# Altium schematic sheet LMcude-F_01.SchDoc(LMcude-F_01)
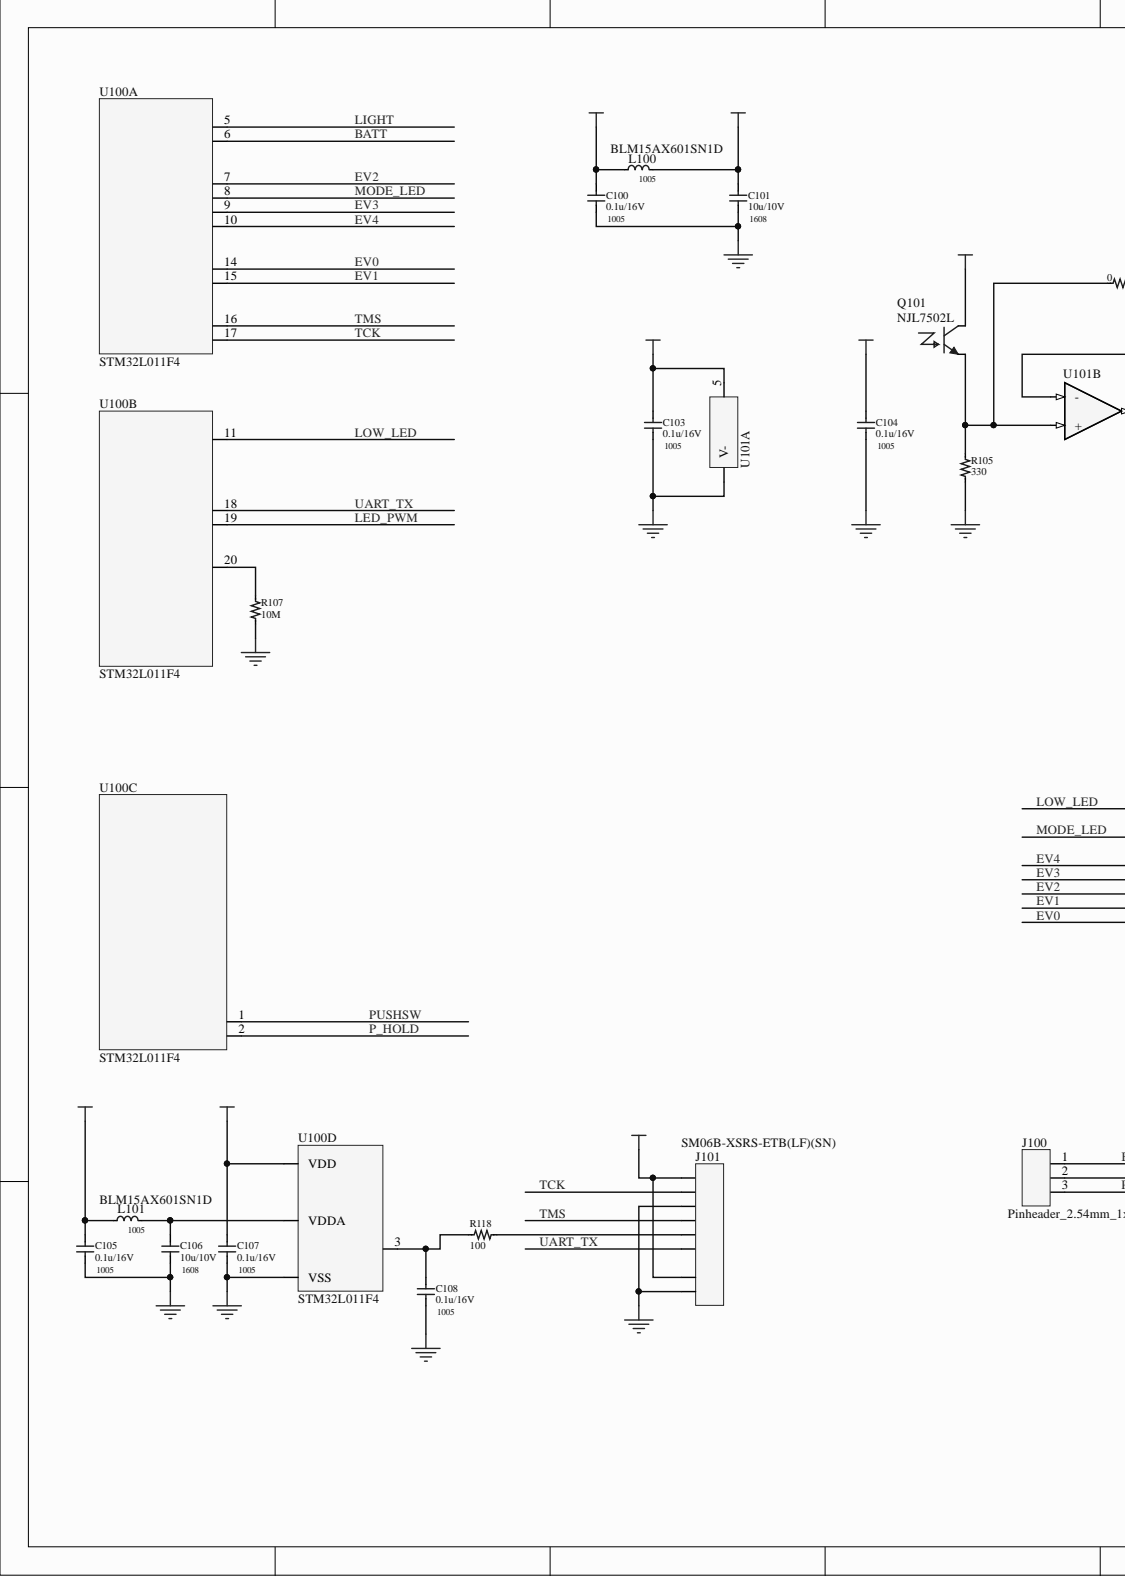
[diagram p0: LMcude-F_01.SchDoc, left]
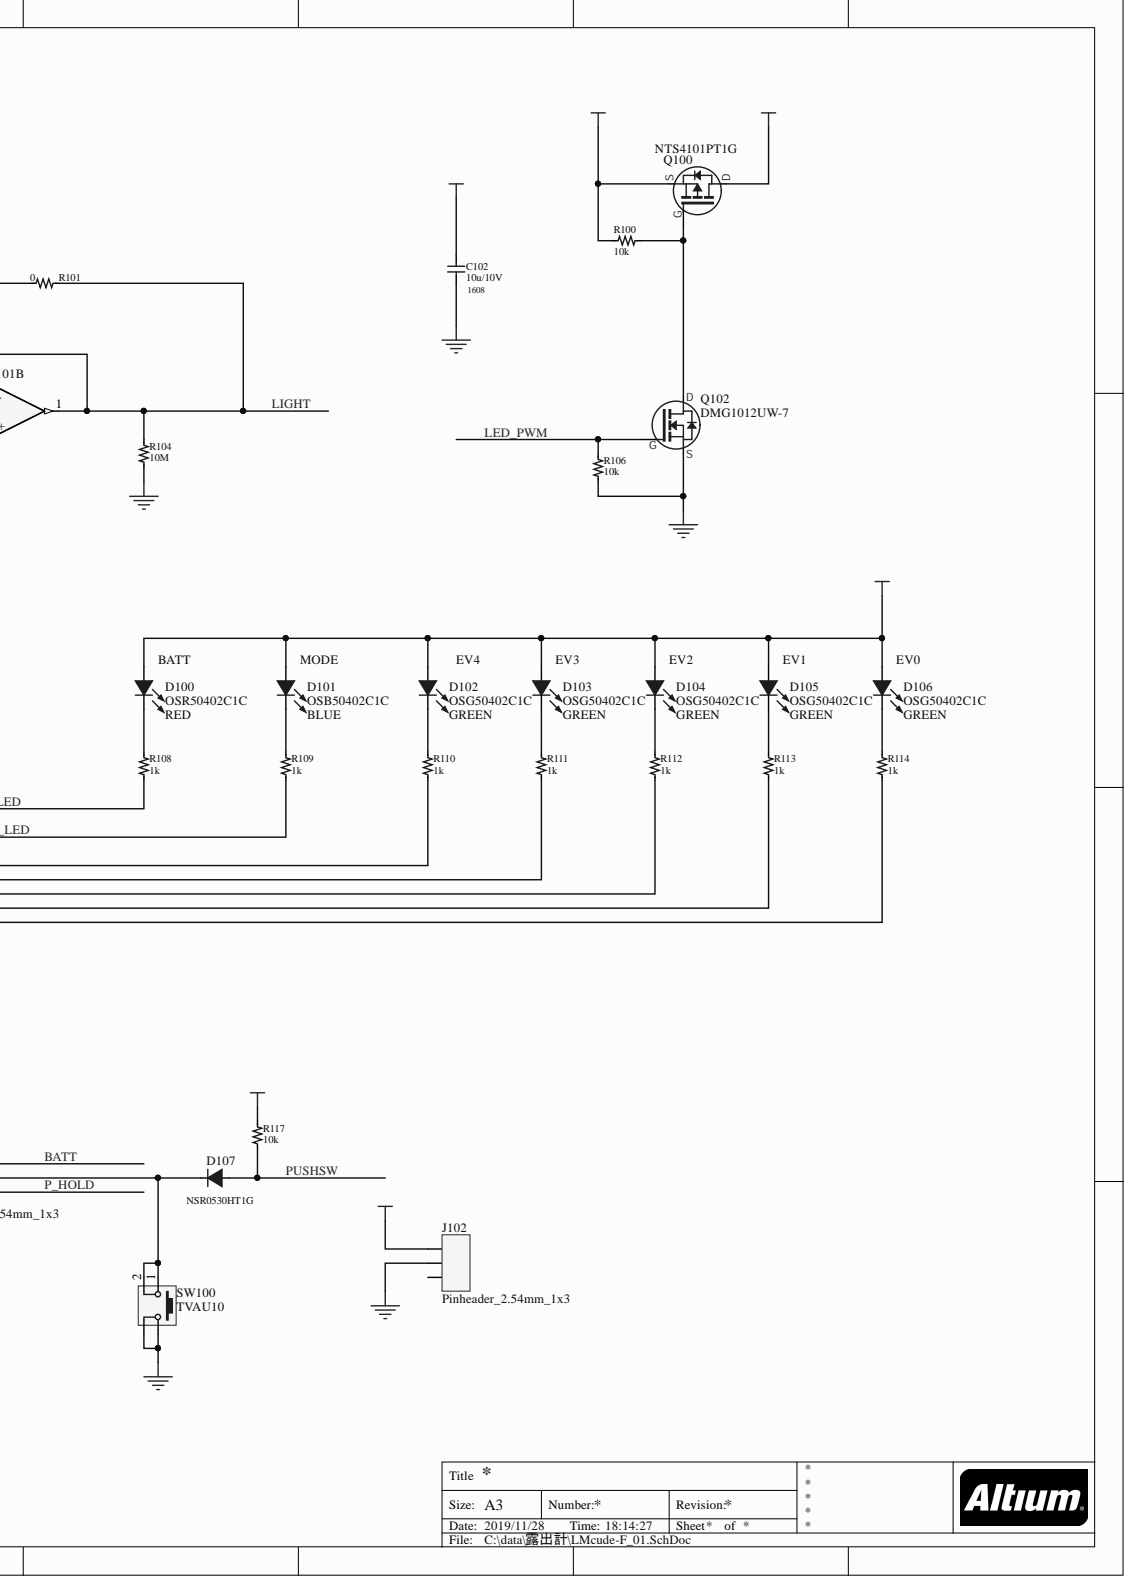
[diagram p1: LMcude-F_01.SchDoc, right]

## Parts
C100  0.1u/16V  Footprint(metric): 1005  [SC2-1.00X0.50A]
C101  10u/10V  Footprint(metric): 1608  [SC2-1.60X0.80A]
C102  10u/10V  Footprint(metric): 1608  [SC2-1.60X0.80A]
C103  0.1u/16V  Footprint(metric): 1005  [SC2-1.00X0.50A]
C104  0.1u/16V  Footprint(metric): 1005  [SC2-1.00X0.50A]
C105  0.1u/16V  Footprint(metric): 1005  [SC2-1.00X0.50A]
C106  10u/10V  Footprint(metric): 1608  [SC2-1.60X0.80A]
C107  0.1u/16V  Footprint(metric): 1005  [SC2-1.00X0.50A]
C108  0.1u/16V  Footprint(metric): 1005  [SC2-1.00X0.50A]
D100  OSR50402C1C  Color: RED  [LED 1005]
D101  OSB50402C1C  Color: BLUE  [LED 1005]
D102  OSG50402C1C  Color: GREEN  [LED 1005]
D103  OSG50402C1C  Color: GREEN  [LED 1005]
D104  OSG50402C1C  Color: GREEN  [LED 1005]
D105  OSG50402C1C  Color: GREEN  [LED 1005]
D106  OSG50402C1C  Color: GREEN  [LED 1005]
D107  NSR0530HT1G  [SOD-323 Onsemi]
J100  Pinheader_2.54mm_1x3  [Pinheader_2.54mm_1x3]
J101  SM06B-XSRS-ETB(LF)(SN)  [SM06B-XSRS-RTB(LF)(SN)]
J102  Pinheader_2.54mm_1x3  [Pinheader_2.54mm_1x3]
L100  BLM15AX601SN1D  Footprint(metric): 1005  [SL2-1.00X0.50A]
L101  BLM15AX601SN1D  Footprint(metric): 1005  [SL2-1.00X0.50A]
Q100  NTS4101PT1G  [ON Semiconductor SC-70(SOT-323)]
Q101  NJL7502L  [3mm DIP PHOTO Transister]
Q102  DMG1012UW-7  [DMG1012UW-7]
R100  10k  [SR2-1.00X0.50A]
R101  0  [SR2-1.00X0.50A]
R104  10M  [SR2-1.00X0.50A]
R105  330  [SR2-1.00X0.50A]
R106  10k  [SR2-1.00X0.50A]
R107  10M  [SR2-1.00X0.50A]
R108  1k  [SR2-1.00X0.50A]
R109  1k  [SR2-1.00X0.50A]
R110  1k  [SR2-1.00X0.50A]
R111  1k  [SR2-1.00X0.50A]
R112  1k  [SR2-1.00X0.50A]
R113  1k  [SR2-1.00X0.50A]
R114  1k  [SR2-1.00X0.50A]
R117  10k  [SR2-1.00X0.50A]
R118  100  [SR2-1.00X0.50A]
SW100  TVAU10  [Switch_TVAU10]
U100  STM32L011F4  [STM32 UFQFPN20]  (parts A,B,C,D)
U101  Manufacture Parts Number  [ABLIC SOT-23-5]  (value not drawn)  (parts A,B)

## Nets
3V3: C100.1 C102.1 C105.1 C107.1 J101.1 J101.FG1 J102.1 L100.1 L101.1 Q100.2 R100.2 R117.1 U100.13(VDD)
3V3LED: D100.A D101.A D102.A D103.A D104.A D105.A D106.A Q100.3
3V3P: C101.1 C103.1 C104.1 L100.2 Q101.C U101.5
GND: C100.2 C101.2 C102.2 C103.2 C104.2 C105.2 C106.2 C107.2 C108.2 J101.3 J101.FG2 J102.2 Q102.2 R104.1 R105.1 R106.2 R107.1 SW100.3 SW100.4 U100.12(VSS) U101.2(V-)
BATT: J100.1 U100.6
EV0: R114.2 U100.14
EV1: R113.2 U100.15
EV2: R112.2 U100.7
EV3: R111.2 U100.9
EV4: R110.2 U100.10
LED_PWM: Q102.1 R106.1 U100.19
LIGHT: R101.1 R104.2 U100.5 U101.1 U101.4(-)
LOW_LED: R108.2 U100.11
MODE_LED: R109.2 U100.8
P_HOLD: J100.3 U100.2
PUSHSW: D107.A R117.2 U100.1
TCK: J101.2 U100.17
TMS: J101.4 U100.16
UART_TX: J101.6 U100.18
NetC106_1: C106.1 L101.2 U100.4(VDDA)
NetC108_1: C108.1 R118.1 U100.3
NetD100_C: D100.C R108.1
NetD101_C: D101.C R109.1
NetD102_C: D102.C R110.1
NetD103_C: D103.C R111.1
NetD104_C: D104.C R112.1
NetD105_C: D105.C R113.1
NetD106_C: D106.C R114.1
NetD107_C: D107.C J100.2 SW100.1 SW100.2
NetJ101_5: J101.5 R118.2
NetQ100_1: Q100.1 Q102.3 R100.1
NetQ101_E: Q101.E R101.2 R105.2 U101.3(+)
NetR107_2: R107.2 U100.20

Not connected: J102 3

## Library data of the parts
C100 C103-C105 C107 C108 = C: CAP CER 0.1UF 16V X7R 0402; Manufacturer Part Number: 0.1uF/16V X7R 1005metric; Manufacturer: Any; Sample Shop: Digikey; Replace: ok; PCB P/N: LMcube; MP SPQ: unknown; Function Category: 5V DCDC; Commodity: MLCC; BOM Rev: 0.00; Value: 0.1u/16V; Sample URL: https://www.digikey.jp/product-detail/ja/murata-electronics/GCM155R71C104KA55J/490-7998-1-ND/4380283; MP Price: unknown; MP MOQ: unknown; MP L/T(w): unknown; MP Dist.: unknown; Footprint(imperial): 0402; Component Kind: Standard; Library Name: I_Lib_cronos.IntLib
C101 C102 C106 = C: CAP CER 4.7UF 16V X5R 0603; Manufacturer Part Number: LMK107BBJ106MALT; Manufacturer: Yuden; Sample Shop: Digikey; Replace: ok; PCB P/N: LMcube; MP SPQ: unknown; Function Category: Battery Charger; Commodity: MLCC; BOM Rev: 0.00; Value: 10u/10V; Sample URL: https://www.digikey.jp/product-detail/ja/taiyo-yuden/LMK107BBJ106MALT/587-3258-1-ND/3662218; MP Price: unknown; MP MOQ: unknown; MP L/T(w): unknown; MP Dist.: unknown; Footprint(imperial): 0603; Component Kind: Standard; Library Name: I_Lib_cronos.IntLib
D100-D106 = LED 1005: RGB LED SMT 5x5mm; Manufacturer: OptoSupply; Sample Shop: AKIDUKI; Replace: ng; PCB P/N: LMcube; MP SPQ: unknown; BOM Rev: 0.00; Value: LED 1005; MP Price: unknown; MP MOQ: unknown; MP L/T(w): unknown; MP Dist.: unknown; Function Category: unknown; Component Kind: Standard; Commodity: LED; Library Name: I_Lib_cronos.IntLib
  D100: Sample URL: http://akizukidenshi.com/catalog/g/gI-11717/; Manufacturer Part Number: OSR50402C1C
  D101: Sample URL: http://akizukidenshi.com/catalog/g/gI-11722/; Manufacturer Part Number: OSB50402C1C
  D102-D106: Sample URL: http://akizukidenshi.com/catalog/g/gI-11721/; Manufacturer Part Number: OSG50402C1C
D107 = NSR0530HT1G: Manufacturer: ONsemi; Sample Shop: Digikey; Replace: ng; PCB P/N: LMcube; MP SPQ: unknown; Function Category: Power Control; Commodity: Diode; BOM Rev: 0.00; Value: NSR0530HT1G; Sample URL: http://www.digikey.jp/product-detail/ja/on-semiconductor/NSR0530HT1G/NSR0530HT1GOSDKR-ND/5761699; MP Price: unknown; MP MOQ: unknown; MP L/T(w): unknown; MP Dist.: unknown; Manufacturer Part Number: NSR0530HT1G; Component Kind: Standard; Library Name: I_Lib_cronos.IntLib
J100 J102 = Con3: Manufacturer Part Number: 2.54mm Lowprofile Header; Manufacturer: Neltron Industrial Co., Ltd.; Sample Shop: AKIDUKI; Replace: ng; PCB P/N: LMcube; MP SPQ: unknown; BOM Rev: 0.00; Value: Pinheader_2.54mm_1x3; Sample URL: http://akizukidenshi.com/catalog/g/gC-02900/; MP Price: unknown; MP MOQ: unknown; MP L/T(w): unknown; MP Dist.: unknown; Function Category: unknown; Component Kind: Standard; Commodity: unknown; Library Name: I_Lib_cronos.IntLib
J101 = Con6 with FG: Manufacturer: JST; Value: Con6; Sample Shop: Digikey; Replace: ng; PCB P/N: LMcube; MP SPQ: unknown; BOM Rev: 0.00; Sample URL: https://www.digikey.jp/product-detail/ja/jst-sales-america-inc/SM06B-XSRS-ETB-LF-SN/455-3943-1-ND/9924352; Others: https://www.digikey.jp/product-detail/ja/jst-sales-america-inc/A06XSR06XSR36R254A/455-3916-ND/9924318; MP Price: unknown; MP MOQ: unknown; MP L/T(w): unknown; MP Dist.: unknown; Manufacturer Part Number: SM06B-XSRS-ETB(LF)(SN); Function Category: unknown; Component Kind: Standard; Commodity: unknown; Library Name: I_Lib_cronos.IntLib
L100 L101 = L: Inductor 2pin; Manufacturer: Murata Electronics; Sample Shop: Digikey; Replace: ng; PCB P/N: LMcube; MP SPQ: unknown; Function Category: ISM RF Transceiver; Commodity: Chip Coil; BOM Rev: 0.00; Sample URL: https://www.digikey.jp/product-detail/ja/murata-electronics/BLM15AX601SN1D/490-5441-1-ND/2256885; MP Price: unknown; MP MOQ: unknown; MP L/T(w): unknown; MP Dist.: unknown; Manufacturer Part Number: BLM15AX601SN1D; Footprint(imperial): 0402; Component Kind: Standard; Library Name: I_Lib_cronos.IntLib
Q100 = Pch_MOSFET: Sample Shop: Digikey; Replace: ng; PCB P/N: LMcube; MP SPQ: unknown; BOM Rev: 0.00; Sample URL: https://www.digikey.jp/product-detail/ja/on-semiconductor/NTS4101PT1G/NTS4101PT1GOSCT-ND/695259; MP Price: unknown; MP MOQ: unknown; MP L/T(w): unknown; MP Dist.: unknown; Manufacturer Part Number: NTS4101PT1G; Function Category: unknown; Component Kind: Standard; Commodity: Pch_MOSFET; Library Name: I_Lib_cronos.IntLib
Q101 = NJL7502L: Manufacturer: JRC; Sample Shop: AKIDUKI; Replace: ng; PCB P/N: LMcube; MP SPQ: unknown; Commodity: Photo Tr; BOM Rev: 0.00; Sample URL: http://akizukidenshi.com/catalog/g/gI-02325/; MP Price: unknown; MP MOQ: unknown; MP L/T(w): unknown; MP Dist.: unknown; Manufacturer Part Number: NJL7502L; Function Category: unknown; Component Kind: Standard; Library Name: I_Lib_cronos.IntLib
Q102 = Nch_MOSFET: Manufacturer: Diodes Incorporated; Sample Shop: Digikey; Replace: ng; PCB P/N: LMcube; MP SPQ: unknown; Function Category: Power Control; BOM Rev: 0.00; Value: DMG1012UW-7; Sample URL: http://www.digikey.jp/product-detail/ja/diodes-incorporated/DMG1012UW-7/DMG1012UW-7DIDKR-ND/2183253; MP Price: unknown; MP MOQ: unknown; MP L/T(w): unknown; MP Dist.: unknown; Manufacturer Part Number: DMG1012UW-7; Component Kind: Standard; Commodity: Nch_MOSFET; Library Name: I_Lib_cronos.IntLib
R100 R106 R117 = R: RES_10k_0402_1%_1/16W; Manufacturer Part Number: 10k 1% 1/16W 1005metric; Manufacturer: Any; Sample Shop: Digikey; Replace: ok; PCB P/N: LMcube; MP SPQ: unknown; Function Category: Power Control; Commodity: Resistor; BOM Rev: 0.00; Value: 10k; Sample URL: https://www.digikey.jp/product-detail/ja/yageo/RC0402FR-0710KL/311-10.0KLRCT-ND/729470; MP Price: unknown; MP MOQ: unknown; MP L/T(w): unknown; MP Dist.: unknown; Footprint(metric): 1005; Footprint(imperial): 0402; Component Kind: Standard; Library Name: I_Lib_cronos.IntLib
R101 = R: RES_0_0402_1%_1/16W; Manufacturer Part Number: 0 1% 1/16W 1005metric; Manufacturer: Any; Sample Shop: Digikey; Replace: ok; PCB P/N: LMcube; MP SPQ: unknown; Function Category: Battery Charger; Commodity: Resistor; BOM Rev: 0.00; Value: 0; Sample URL: https://www.digikey.jp/product-detail/ja/yageo/RC0402JR-070RL/311-0.0JRCT-ND/729353; MP Price: unknown; MP MOQ: unknown; MP L/T(w): unknown; MP Dist.: unknown; Footprint(metric): 1005; Footprint(imperial): 0402; Component Kind: Standard; Library Name: I_Lib_cronos.IntLib
R104 R107 = R: RES_10M_0402_1%_1/16W; Manufacturer Part Number: 10M 1% 1/16W 1005metric; Manufacturer: Any; Sample Shop: Digikey; Replace: ok; PCB P/N: LMcube; MP SPQ: unknown; Function Category: MPU Block; Commodity: Resistor; BOM Rev: 0.00; Value: 10M; Sample URL: https://www.digikey.jp/product-detail/ja/yageo/RC0402FR-0710ML/311-10.0MLRCT-ND/2827890; MP Price: unknown; MP MOQ: unknown; MP L/T(w): unknown; MP Dist.: unknown; Footprint(metric): 1005; Footprint(imperial): 0402; Component Kind: Standard; Library Name: I_Lib_cronos.IntLib
R105 = R: RES_330_0402_1%_1/16W; Manufacturer Part Number: 330 1% 1/16W 1005metric; Manufacturer: Any; Sample Shop: Digikey; Replace: ok; PCB P/N: LMcube; MP SPQ: unknown; Function Category: MPU Block; Commodity: Resistor; BOM Rev: 0.00; Value: 330; Sample URL: https://www.digikey.jp/product-detail/ja/yageo/RC0402FR-07330RL/311-330LRCT-ND/729541; MP Price: unknown; MP MOQ: unknown; MP L/T(w): unknown; MP Dist.: unknown; Footprint(metric): 1005; Footprint(imperial): 0402; Component Kind: Standard; Library Name: I_Lib_cronos.IntLib
R108-R114 = R: RES_1k_0402_1%_1/16W; Manufacturer Part Number: 1k 1% 1/16W 1005metric; Manufacturer: Any; Sample Shop: Digikey; Replace: ok; PCB P/N: LMcube; MP SPQ: unknown; Function Category: Power Control; Commodity: Resistor; BOM Rev: 0.00; Value: 1k; Sample URL: https://www.digikey.jp/product-detail/ja/yageo/RT0402FRE071KL/YAG1234CT-ND/4340587; MP Price: unknown; MP MOQ: unknown; MP L/T(w): unknown; MP Dist.: unknown; Footprint(metric): 1005; Footprint(imperial): 0402; Component Kind: Standard; Library Name: I_Lib_cronos.IntLib
R118 = R: RES_100_0402_1%_1/16W; Manufacturer Part Number: 100 1% 1/16W 1005metric; Manufacturer: Any; Sample Shop: Digikey; Replace: ok; PCB P/N: LMcube; MP SPQ: unknown; Function Category: Power Control; Commodity: Resistor; BOM Rev: 0.00; Value: 100; Sample URL: https://www.digikey.jp/product-detail/ja/yageo/RC0402FR-07100RL/311-100LRCT-ND/729474; MP Price: unknown; MP MOQ: unknown; MP L/T(w): unknown; MP Dist.: unknown; Footprint(metric): 1005; Footprint(imperial): 0402; Component Kind: Standard; Library Name: I_Lib_cronos.IntLib
SW100 = TVAU10: SWITCH TACTILE 0.05A 12V; Manufacturer Part Number: PTS815 SJM 250 SMTR LFS; Manufacturer: C&K; Sample Shop: Digikey; PCB P/N: LMcube; MP SPQ: unknown; Commodity: TactSwitch; BOM Rev: 0.00; Sample URL: https://www.digikey.jp/product-detail/ja/c-k/PTS815-SJM-250-SMTR-LFS/CKN12215-1-ND/9947847; MP Price: unknown; MP MOQ: unknown; MP L/T(w): unknown; MP Dist.: unknown; Function Category: unknown; Component Kind: Standard; Library Name: I_Lib_cronos.IntLib
U100 = STM32L011F4: Manufacturer Part Number: STM32L011F4U6TR; Manufacturer: STmicro; Sample Shop: Digikey; Replace: ng; PCB P/N: LMcube; MP SPQ: unknown; Function Category: MPU; BOM Rev: 0.00; Value: STM32L011F4; Sample URL: https://www.digikey.jp/product-detail/ja/stmicroelectronics/STM32L011F4U6TR/497-17478-1-ND/7804397; MP Price: unknown; MP MOQ: unknown; MP L/T(w): unknown; MP Dist.: unknown; Component Kind: Standard; Commodity: MPU; Library Name: I_Lib_cronos.IntLib
U101 = S-89431ACMC-HBVT2U: Manufacturer: ABLIC; Sample Shop: AKIDUKI; Replace: ng; PCB P/N: LMcube; MP SPQ: unknown; Commodity: OPAMP; BOM Rev: 0.00; Sample URL: http://akizukidenshi.com/catalog/g/gI-11169/; MP Price: unknown; MP MOQ: unknown; MP L/T(w): unknown; MP Dist.: unknown; Manufacturer Part Number: S-89431ACMC-HBVT2U; Function Category: unknown; Component Kind: Standard; Library Name: I_Lib_cronos.IntLib
